# Altium schematic sheet I2CKeypad.SchDoc
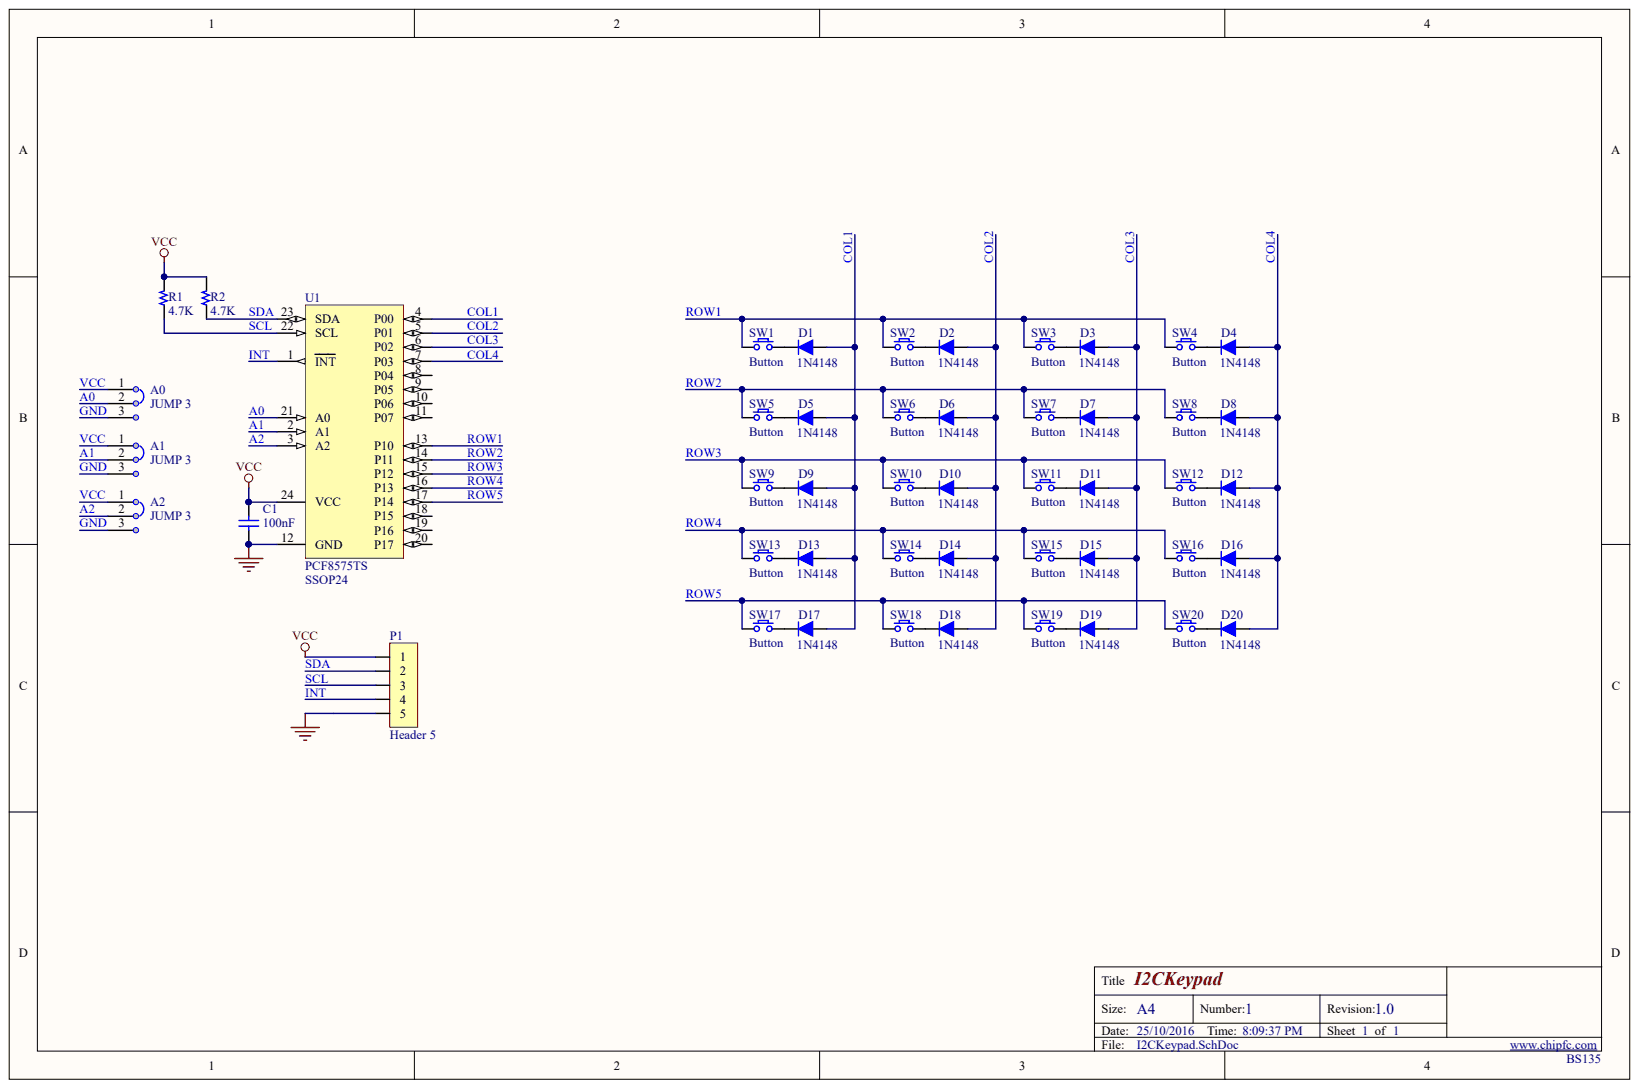
[diagram p0: I2CKeypad.SchDoc]

## Parts
A0  JUMP 3  [JUMP3 SMD]
A1  JUMP 3  [JUMP3 SMD]
A2  JUMP 3  [JUMP3 SMD]
C1  100nF  [C 0805]
D1  1N4148  [Diode SOD80C Wave]
D2  1N4148  [Diode SOD80C Wave]
D3  1N4148  [Diode SOD80C Wave]
D4  1N4148  [Diode SOD80C Wave]
D5  1N4148  [Diode SOD80C Wave]
D6  1N4148  [Diode SOD80C Wave]
D7  1N4148  [Diode SOD80C Wave]
D8  1N4148  [Diode SOD80C Wave]
D9  1N4148  [Diode SOD80C Wave]
D10  1N4148  [Diode SOD80C Wave]
D11  1N4148  [Diode SOD80C Wave]
D12  1N4148  [Diode SOD80C Wave]
D13  1N4148  [Diode SOD80C Wave]
D14  1N4148  [Diode SOD80C Wave]
D15  1N4148  [Diode SOD80C Wave]
D16  1N4148  [Diode SOD80C Wave]
D17  1N4148  [Diode SOD80C Wave]
D18  1N4148  [Diode SOD80C Wave]
D19  1N4148  [Diode SOD80C Wave]
D20  1N4148  [Diode SOD80C Wave]
P1  Header 5  [Header 5]
R1  4.7K  [R 0805]
R2  4.7K  [R 0805]
SW1  Button  [SW/2/DIP]
SW2  Button  [SW/2/DIP]
SW3  Button  [SW/2/DIP]
SW4  Button  [SW/2/DIP]
SW5  Button  [SW/2/DIP]
SW6  Button  [SW/2/DIP]
SW7  Button  [SW/2/DIP]
SW8  Button  [SW/2/DIP]
SW9  Button  [SW/2/DIP]
SW10  Button  [SW/2/DIP]
SW11  Button  [SW/2/DIP]
SW12  Button  [SW/2/DIP]
SW13  Button  [SW/2/DIP]
SW14  Button  [SW/2/DIP]
SW15  Button  [SW/2/DIP]
SW16  Button  [SW/2/DIP]
SW17  Button  [SW/2/DIP]
SW18  Button  [SW/2/DIP]
SW19  Button  [SW/2/DIP]
SW20  Button  [SW/2/DIP]
U1  PCF8575TS  PACKAGE: SSOP24  [SSOP24/PCF8575TS]

## Nets
A0: A0.2 U1.21(A0)
A1: A1.2 U1.2(A1)
A2: A2.2 U1.3(A2)
COL1: D1.1 D5.1 D9.1 D13.1 D17.1 U1.4(P00)
COL2: D2.1 D6.1 D10.1 D14.1 D18.1 U1.5(P01)
COL3: D3.1 D7.1 D11.1 D15.1 D19.1 U1.6(P02)
COL4: D4.1 D8.1 D12.1 D16.1 D20.1 U1.7(P03)
GND: A0.3 A1.3 A2.3 C1.2 P1.5 U1.12(GND)
INT: P1.4 U1.1(INT)
ROW1: SW1.1 SW2.1 SW3.1 SW4.1 U1.13(P10)
ROW2: SW5.1 SW6.1 SW7.1 SW8.1 U1.14(P11)
ROW3: SW9.1 SW10.1 SW11.1 SW12.1 U1.15(P12)
ROW4: SW13.1 SW14.1 SW15.1 SW16.1 U1.16(P13)
ROW5: SW17.1 SW18.1 SW19.1 SW20.1 U1.17(P14)
SCL: P1.3 R1.1 U1.22(SCL)
SDA: P1.2 R2.1 U1.23(SDA)
VCC: A0.1 A1.1 A2.1 C1.1 P1.1 R1.2 R2.2 U1.24(VCC)
NetD1_2: D1.2 SW1.2
NetD2_2: D2.2 SW2.2
NetD3_2: D3.2 SW3.2
NetD4_2: D4.2 SW4.2
NetD5_2: D5.2 SW5.2
NetD6_2: D6.2 SW6.2
NetD7_2: D7.2 SW7.2
NetD8_2: D8.2 SW8.2
NetD9_2: D9.2 SW9.2
NetD10_2: D10.2 SW10.2
NetD11_2: D11.2 SW11.2
NetD12_2: D12.2 SW12.2
NetD13_2: D13.2 SW13.2
NetD14_2: D14.2 SW14.2
NetD15_2: D15.2 SW15.2
NetD16_2: D16.2 SW16.2
NetD17_2: D17.2 SW17.2
NetD18_2: D18.2 SW18.2
NetD19_2: D19.2 SW19.2
NetD20_2: D20.2 SW20.2

Not connected: U1 8(P04) 9(P05) 10(P06) 11(P07) 18(P15) 19(P16) 20(P17)

## Library data of the parts
C1 = Capacitor NP: Capacitor non-pol; Component Kind: Standard; Library Name: ChipFC_Lib.IntLib
D1-D20 = Diode: Default Diode; Component Kind: Standard; Library Name: ChipFC_Lib.IntLib
P1 = Header 5: Header, 5-Pin; Component Kind: Standard; Library Name: ChipFC_Lib.IntLib
R1 R2 = Resistor: Component Kind: Standard; Description: Resistor; Library Name: ChipFC_Lib.IntLib
SW1-SW20 = SW SPST: Tact switch 2 pin; Component Kind: Standard; Library Name: ChipFC_Lib.IntLib
U1 = PCF8575TS: PCF8575 Remote16-BIT I  2C AND SMBus I/O Expander  with Interrupt Output; BRAND: NXP; Component Kind: Standard; Library Name: ChipFC_Lib.IntLib; PART NO: PCF8575TS; TYPE: SMD
A0-A2 = JUMP 3: Component Kind: Standard; Library Name: ChipFC_Lib.IntLib
